# Altium schematic sheet TI_电机驱动2.0.SchDoc
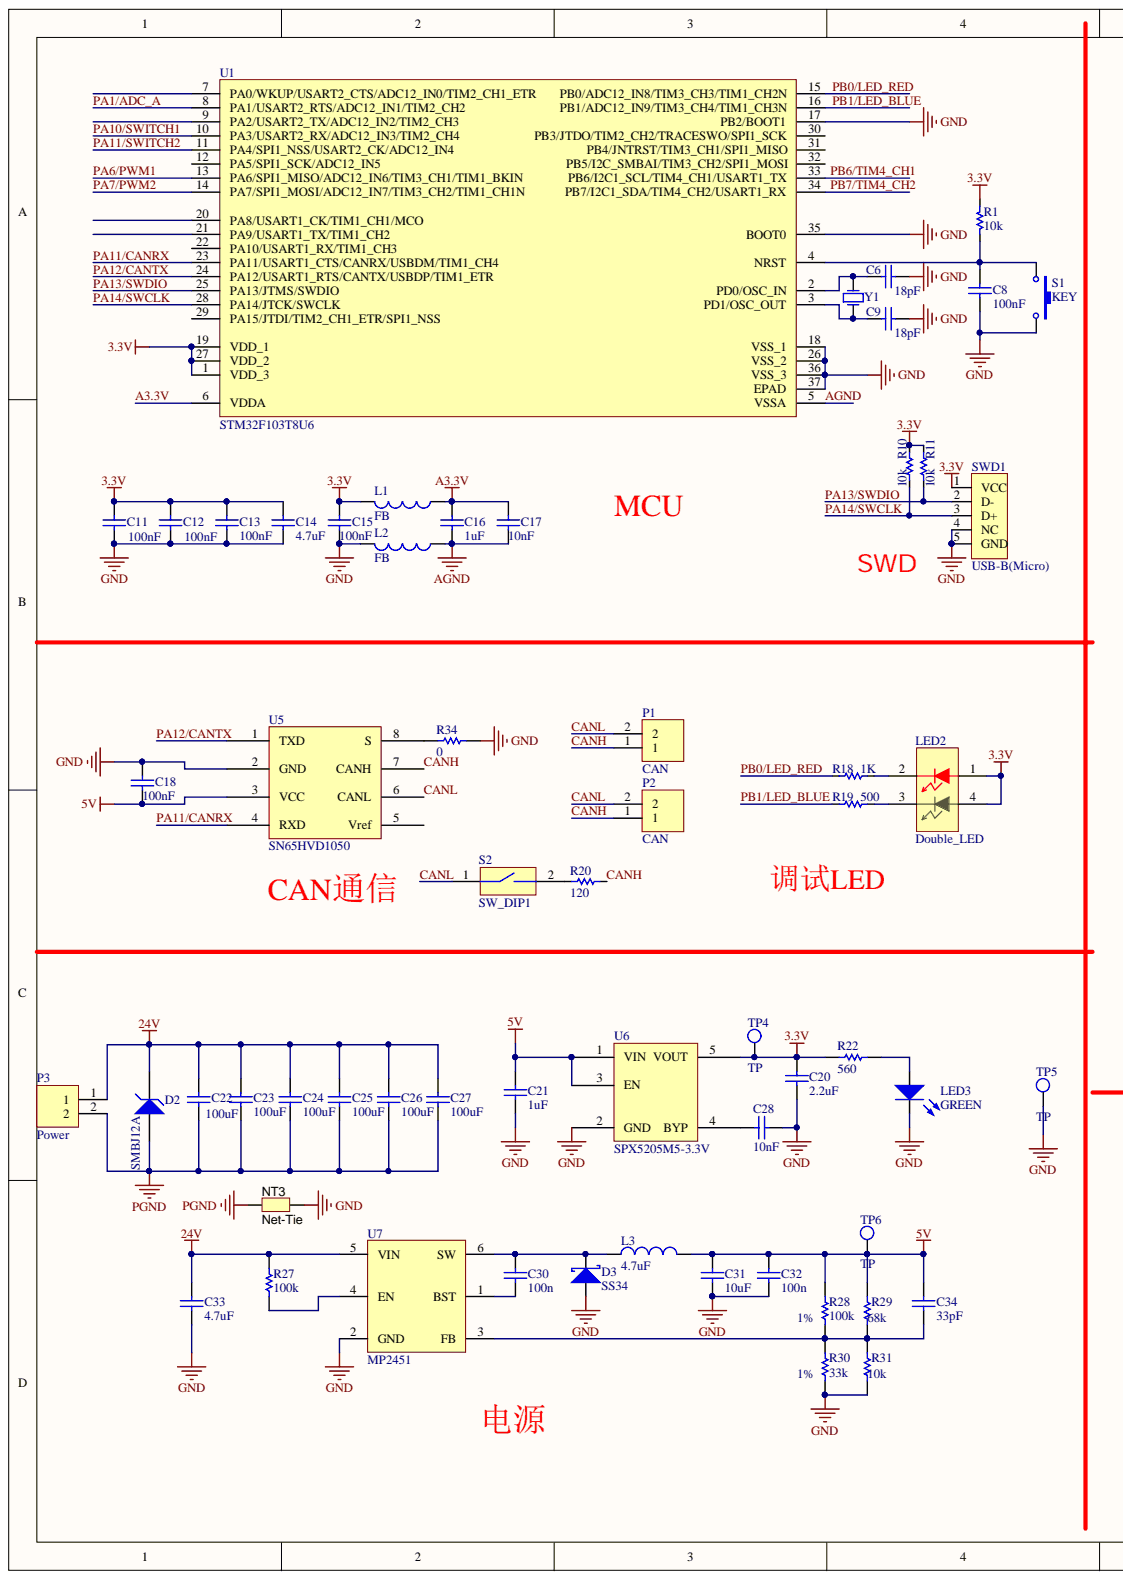
[diagram p0: TI_电机驱动2.0.SchDoc, left]
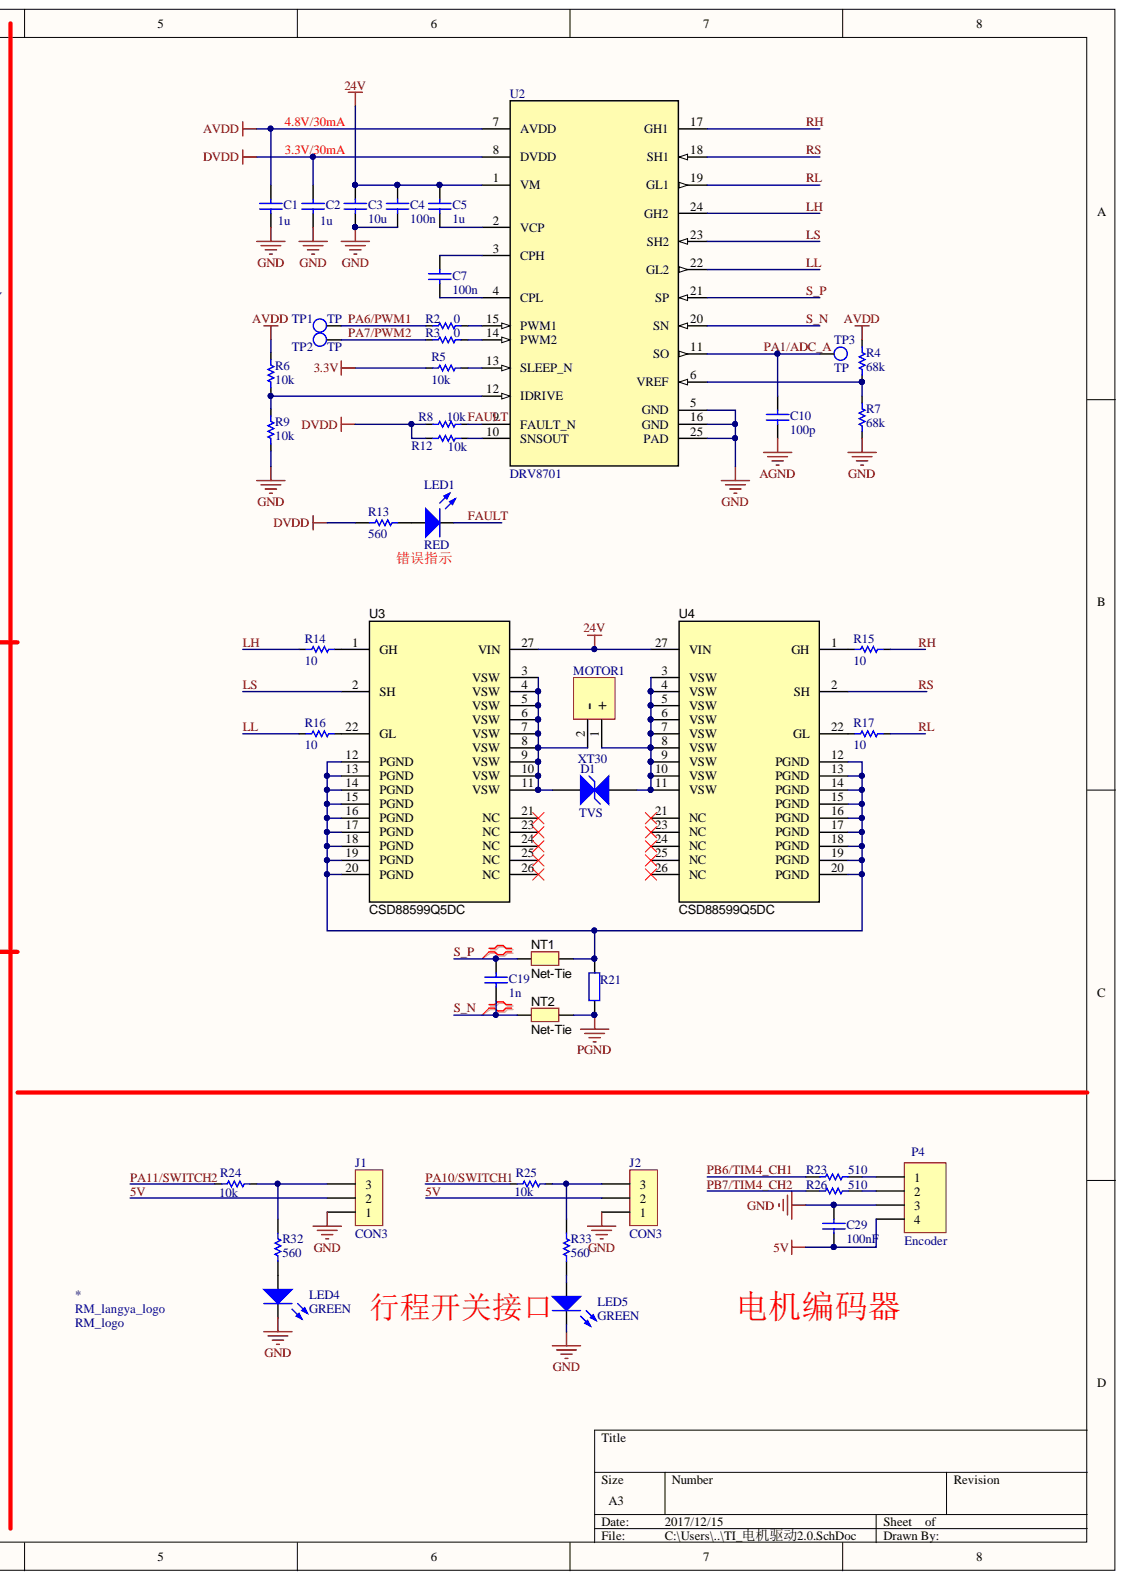
[diagram p1: TI_电机驱动2.0.SchDoc, right]

## Parts
*  RM_logo  [RM_logo 300mil*350]
C1  value 1u  [0603C]  (hidden comment: 1uF/0603/50V)
C2  value 1u  [0603C]  (hidden comment: 1uF/0603/50V)
C3  value 10u  [0805C]  (hidden comment: 1uF/0603/50V)
C4  value 100n  [0603C]  (hidden comment: 1uF/0603/50V)
C5  value 1u  [0603C]  (hidden comment: 1uF/0603/50V)
C6  18pF  [0603C]
C7  value 100n  [0603C]  (hidden comment: 1uF/0603/50V)
C8  100nF  [0603C]
C9  18pF  [0603C]
C10  value 100p  [0603C]  (hidden comment: 1uF/0603/50V)
C11  100nF  [0603C]
C12  100nF  [0603C]
C13  100nF  [0603C]
C14  4.7uF  [0603C]
C15  100nF  [0603C]
C16  1uF  [0603C]
C17  10nF  [0603C]
C18  100nF  [0603C]
C19  value 1n  [0603C]  (hidden comment: 1uF/0603/50V)
C20  value 2.2uF  [0603C]  (hidden comment: 1uF/0603/50V)
C21  value 1uF  [0603C]  (hidden comment: 1uF/0603/50V)
C22  value 100uF  [1206C]  (hidden comment: 1uF/0603/50V)
C23  value 100uF  [1206C]  (hidden comment: 1uF/0603/50V)
C24  value 100uF  [1206C]  (hidden comment: 1uF/0603/50V)
C25  value 100uF  [1206C]  (hidden comment: 1uF/0603/50V)
C26  value 100uF  [1206C]  (hidden comment: 1uF/0603/50V)
C27  value 100uF  [1206C]  (hidden comment: 1uF/0603/50V)
C28  value 10nF  [0603C]  (hidden comment: 1uF/0603/50V)
C29  value 100nF  [0603c]  (hidden comment: Cap)
C30  value 100n  [0603C]  (hidden comment: 1uF/0603/50V)
C31  value 10uF  [0805C]  (hidden comment: 1uF/0603/50V)
C32  value 100n  [0603C]  (hidden comment: 1uF/0603/50V)
C33  value 4.7uF  [1206C]  (hidden comment: 1uF/0603/50V)
C34  value 33pF  [0603C]  (hidden comment: 1uF/0603/50V)
D1  TVS  [SMB/DO-214AA]
D2  SMBJ12A  [SMB/DO-214AA]
D3  SS34  [SOD-323]
J1  CON3  [A1254-03AWB]
J2  CON3  [A1254-03AWB]
L1  FB  [0603L]
L2  FB  [0603L]
L3  value 4.7uF  [4020L]  (hidden comment: 10mH/0402)
LED1  RED  [0603LED_R]
LED2  Double_LED  [0805LEDX2]
LED3  GREEN  [0603LED_G]
LED4  GREEN  [0603LED_G]
LED5  GREEN  [0603LED_G]
MOTOR1  XT30  [XT30PW-Female]
NT1  Net-Tie  [Tie_1]
NT2  Net-Tie  [Tie_1]
NT3  Net-Tie  [Tie_2]
P1  CAN  [MX3.0MM 2X1AW]
P2  CAN  [MX3.0MM 2X1AW]
P3  Power  [XT30PW-Male]
P4  Encoder  [MX3.0mm 2X2AW]
R1  10k  [0603R]
R2  value 0  [0603R]  (hidden comment: 1K/5%)
R3  value 0  [0603R]  (hidden comment: 1K/5%)
R4  value 68k  [0603R]  (hidden comment: 1K/5%)
R5  value 10k  [0603R]  (hidden comment: 1K/5%)
R6  value 10k  [0603R]  (hidden comment: 1K/5%)
R7  value 68k  [0603R]  (hidden comment: 1K/5%)
R8  value 10k  [0603R]  (hidden comment: 1K/5%)
R9  value 10k  [0603R]  (hidden comment: 1K/5%)
R10  10k  [0603R]
R11  10k  [0603R]
R12  value 10k  [0603R]  (hidden comment: 1K/5%)
R13  value 560  [0603R]  (hidden comment: Res1)
R14  value 10  [0603R]  (hidden comment: 1K/5%)
R15  value 10  [0603R]  (hidden comment: 1K/5%)
R16  value 10  [0603R_1]  (hidden comment: 1K/5%)
R17  value 10  [0603R]  (hidden comment: 1K/5%)
R18  1K  [0603R]
R19  500  [0603R]
R20  value 120  [0603R]  (hidden comment: 1K/5%)
R21  0.05R/2512/1%  [2512]  (value not drawn)
R22  value 560  [0603R]  (hidden comment: Res1)
R23  value 510  [0603R]  (hidden comment: Res1)
R24  value 10k  [0603R]  (hidden comment: 1K/5%)
R25  value 10k  [0603R]  (hidden comment: 1K/5%)
R26  value 510  [0603R]  (hidden comment: Res1)
R27  value 100k  [0603R]  (hidden comment: Res1)
R28  1%  value 100k  [0603R]
R29  value 68k  [0603R]  (hidden comment: Res1)
R30  1%  value 33k  [0603R]
R31  value 10k  [0603R]  (hidden comment: Res1)
R32  value 560  [0603R_1]  (hidden comment: Res1)
R33  value 560  [0603R_1]  (hidden comment: Res1)
R34  value 0  [0603R_1]  (hidden comment: Res1)
S1  KEY  [SW-3x6x2.5mm]
S2  SW_DIP1  [SW_DIP_1X2]
SWD1  USB-B(Micro)  [USB-micro_A]
TP1  TP  [TP_SMT]
TP2  TP  [TP_SMT]
TP3  TP  [TP_SMT]
TP4  TP  [TP_SMT]
TP5  TP  [TP_VIA]
TP6  TP  [TP_SMT]
U1  STM32F103T8U6  [VFQFPN-36-M]
U2  DRV8701  [RGE0024F]
U3  CSD88599Q5DC  [DMM0022A]
U4  CSD88599Q5DC  [DMM0022A]
U5  SN65HVD1050  [SOIC-8]
U6  SPX5205M5-3.3V  [SOT-23-5 L]
U7  MP2451  [SOT23-6]
Y1  XTAL  [NX5032GA]  (value not drawn)

## Nets
3.3V: C11.2 C12.2 C13.1 C14.1 C15.1 C20.2 L1.1 LED2.1 LED2.4 R1.2 R5.1 R10.1 R11.1 R22.2 SWD1.1(VCC) TP4.1 U1.1(VDD_3) U1.19(VDD_1) U1.27(VDD_2) U6.5(VOUT)
24V: C3.2 C4.2 C5.2 C22.2 C23.2 C24.2 C25.2 C26.2 C27.2 C33.2 D2.2 P3.1 R27.2 U2.1(VM) U3.27(VIN) U4.27(VIN) U7.5(VIN)
AVDD: C1.2 R4.2 R6.2 U2.7(AVDD)
DVDD: C2.2 R8.1 R12.1 R13.2 U2.8(DVDD)
GND: C1.1 C2.1 C3.1 C4.1 C6.2 C8.2 C9.2 C11.1 C12.1 C13.2 C14.2 C15.2 C18.1 C20.1 C21.1 C28.1 C29.1 C31.1 C32.1 C33.1 D3.1 J1.1 J2.1 L2.1 LED3.2 LED4.2 LED5.2 NT3.2 P4.3 R7.1 R9.1 R30.1 R31.1 R34.1 S1.1 SWD1.4(NC) SWD1.5(GND) TP5.1 U1.17(PB2/BOOT1) U1.18(VSS_1) U1.26(VSS_2) U1.35(BOOT0) U1.36(VSS_3) U1.37(EPAD) U2.5(GND) U2.16(GND) U2.25(PAD) U5.2(GND) U6.2(GND) U7.2(GND)
PGND: C22.1 C23.1 C24.1 C25.1 C26.1 C27.1 D2.1 NT2.2 NT3.1 P3.2 R21.1
5V: C18.2 C21.2 C29.2 C31.2 C32.2 C34.1 J1.2 J2.2 L3.2 P4.4 R28.2 R29.2 TP6.1 U5.3(VCC) U6.1(VIN) U6.3(EN)
A3.3V: C16.2 C17.1 L1.2 U1.6(VDDA)
AGND: C10.1 C16.1 C17.2 L2.2 U1.5(VSSA)
CANH: P1.1 P2.1 R20.1 U5.7(CANH)
CANL: P1.2 P2.2 S2.1 U5.6(CANL)
FAULT: LED1.2 R8.2 U2.9(FAULT_N)
LH: R14.1 U2.24(GH2)
LL: R16.1 U2.22(GL2)
LS: U2.23(SH2) U3.2(SH)
PA1/ADC_A: C10.2 TP3.1 U1.8(PA1/USART2_RTS/ADC12_IN1/TIM2_CH2) U2.11(SO)
PA6/PWM1: R2.1 TP1.1 U1.13(PA6/SPI1_MISO/ADC12_IN6/TIM3_CH1/TIM1_BKIN)
PA7/PWM2: R3.1 TP2.1 U1.14(PA7/SPI1_MOSI/ADC12_IN7/TIM3_CH2/TIM1_CH1N)
PA10/SWITCH1: R25.2 U1.10(PA3/USART2_RX/ADC12_IN3/TIM2_CH4)
PA11/CANRX: U1.23(PA11/USART1_CTS/CANRX/USBDM/TIM1_CH4) U5.4(RXD)
PA11/SWITCH2: R24.2 U1.11(PA4/SPI1_NSS/USART2_CK/ADC12_IN4)
PA12/CANTX: U1.24(PA12/USART1_RTS/CANTX/USBDP/TIM1_ETR) U5.1(TXD)
PA13/SWDIO: R11.2 SWD1.2(D-) U1.25(PA13/JTMS/SWDIO)
PA14/SWCLK: R10.2 SWD1.3(D+) U1.28(PA14/JTCK/SWCLK)
PB0/LED_RED: R18.2 U1.15(PB0/ADC12_IN8/TIM3_CH3/TIM1_CH2N)
PB1/LED_BLUE: R19.2 U1.16(PB1/ADC12_IN9/TIM3_CH4/TIM1_CH3N)
PB6/TIM4_CH1: R23.1 U1.33(PB6/I2C1_SCL/TIM4_CH1/USART1_TX)
PB7/TIM4_CH2: R26.1 U1.34(PB7/I2C1_SDA/TIM4_CH2/USART1_RX)
RH: R15.2 U2.17(GH1)
RL: R17.2 U2.19(GL1)
RS: U2.18(SH1) U4.2(SH)
S_N: C19.1 NT2.1 U2.20(SN)
S_P: C19.2 NT1.1 U2.21(SP)
NetC5_1: C5.1 U2.2(VCP)
NetC6_1: C6.1 U1.2(PD0/OSC_IN) Y1.2
NetC7_1: C7.1 U2.4(CPL)
NetC7_2: C7.2 U2.3(CPH)
NetC8_1: C8.1 R1.1 S1.2 U1.4(NRST)
NetC9_1: C9.1 U1.3(PD1/OSC_OUT) Y1.1
NetC28_2: C28.2 U6.4(BYP)
NetC30_1: C30.1 U7.1(BST)
NetC30_2: C30.2 D3.2 L3.1 U7.6(SW)
NetC34_2: C34.2 R28.1 R29.1 R30.2 R31.2 U7.3(FB)
NetD1_1: D1.1 MOTOR1.1 U4.3(VSW) U4.4(VSW) U4.5(VSW) U4.6(VSW) U4.7(VSW) U4.8(VSW) U4.9(VSW) U4.10(VSW) U4.11(VSW)
NetD1_2: D1.2 MOTOR1.2 U3.3(VSW) U3.4(VSW) U3.5(VSW) U3.6(VSW) U3.7(VSW) U3.8(VSW) U3.9(VSW) U3.10(VSW) U3.11(VSW)
NetJ1_3: J1.3 R24.1 R32.2
NetJ2_3: J2.3 R25.1 R33.2
NetLED1_1: LED1.1 R13.1
NetLED2_2: LED2.2 R18.1
NetLED2_3: LED2.3 R19.1
NetLED3_1: LED3.1 R22.1
NetLED4_1: LED4.1 R32.1
NetLED5_1: LED5.1 R33.1
NetNT1_2: NT1.2 R21.2 U3.12(PGND) U3.13(PGND) U3.14(PGND) U3.15(PGND) U3.16(PGND) U3.17(PGND) U3.18(PGND) U3.19(PGND) U3.20(PGND) U4.12(PGND) U4.13(PGND) U4.14(PGND) U4.15(PGND) U4.16(PGND) U4.17(PGND) U4.18(PGND) U4.19(PGND) U4.20(PGND)
NetP4_1: P4.1 R23.2
NetP4_2: P4.2 R26.2
NetR2_2: R2.2 U2.15(PWM1)
NetR3_2: R3.2 U2.14(PWM2)
NetR4_1: R4.1 R7.2 U2.6(VREF)
NetR5_2: R5.2 U2.13(SLEEP_N)
NetR6_1: R6.1 R9.2 U2.12(IDRIVE)
NetR12_2: R12.2 U2.10(SNSOUT)
NetR14_2: R14.2 U3.1(GH)
NetR15_1: R15.1 U4.1(GH)
NetR16_2: R16.2 U3.22(GL)
NetR17_1: R17.1 U4.22(GL)
NetR20_2: R20.2 S2.2
NetR27_1: R27.1 U7.4(EN)
NetR34_2: R34.2 U5.8(S)

Not connected: U1 7(PA0/WKUP/USART2_CTS/ADC12_IN0/TIM2_CH1_ETR) 9(PA2/USART2_TX/ADC12_IN2/TIM2_CH3) 12(PA5/SPI1_SCK/ADC12_IN5) 20(PA8/USART1_CK/TIM1_CH1/MCO) 21(PA9/USART1_TX/TIM1_CH2) 22(PA10/USART1_RX/TIM1_CH3) 29(PA15/JTDI/TIM2_CH1_ETR/SPI1_NSS) 30(PB3/JTDO/TIM2_CH2/TRACESWO/SPI1_SCK) 31(PB4/JNTRST/TIM3_CH1/SPI1_MISO) 32(PB5/I2C_SMBAI/TIM3_CH2/SPI1_MOSI); U3 21(NC) 23(NC) 24(NC) 25(NC) 26(NC); U4 21(NC) 23(NC) 24(NC) 25(NC) 26(NC); U5 5(Vref)

## Library data of the parts
C1-C5 C7 C10 C19-C34 = Cap: Capacitor; Component Kind: Standard; LatestRevisionDate: 17-Jul-2002; LatestRevisionNote: Re-released for DXP Platform.; PackageDescription: Radial Cap, Thru-Hole; 2 Leads; 0.3 in Pin Spacing; PackageReference: RAD-0.3; Published: 8-Jun-2000; Signal Integrity: Cap; Simulation: CAP; Publisher: Altium Limited
  C1-C5 C7 C10 C19-C28 C30-C34: Library Name: MyComponents.IntLib
  C29: Library Name: Miscellaneous Devices.IntLib
C6 C8 C9 C11-C18 = Cap: Capacitor; Value: 100pF; Component Kind: Standard; LatestRevisionDate: 17-Jul-2002; LatestRevisionNote: Re-released for DXP Platform.; PackageDescription: Radial Cap, Thru-Hole; 2 Leads; 0.3 in Pin Spacing; PackageReference: RAD-0.3; Published: 8-Jun-2000; Signal Integrity: Cap; Simulation: CAP; Publisher: Altium Limited
  C6 C8 C9 C11-C17: Library Name: MyComponents.SchLib
  C18: Library Name: MyComponents.IntLib
D2 = D Zener: Zener Diode; Component Kind: Standard; LatestRevisionDate: 17-Jul-2002; LatestRevisionNote: Re-released for DXP Platform.; Library Name: MyComponents.IntLib; PackageDescription: Diode, Thru-Hole; 2 Leads; 0.7 in Pin Spacing; PackageReference: DIODE-0.7; Published: 8-Jun-2000; Signal Integrity: D Zener; Simulation: ZENER; Publisher: Altium Limited
D3 = D Schottky: Schottky Diode; Code_JEDEC: DO-214-AA; Component Kind: Standard; LatestRevisionDate: 17-Jul-2002; LatestRevisionNote: Re-released for DXP Platform.; Library Name: MyComponents.IntLib; PackageDescription: DO-214-AA/SMB; 2 C-Bend Leads; Body 5.3 x 3.6 mm, inc. leads (LxW); PackageReference: SMB; Published: 8-Jun-2000; Signal Integrity: D Schottky; Simulation: SKYDIODE; Publisher: Altium Limited
J1 J2 = CON3: Connector; Component Kind: Standard; Library Name: Robomasters.SchLib
L1 L2 = Inductor: Code_IEC: 1005; Value: 10mH; Code_JEITA: 0402; Component Kind: Standard; Description: Inductor; LatestRevisionDate: 29-May-2009; LatestRevisionNote: IPC-7351 Footprint Added.; Library Name: MyComponents.IntLib; PackageDescription: Chip Inductor; PackageReference: 0402-A; Published: 8-Jun-2000; Signal Integrity: Inductor; Simulation: INDUCTOR; Publisher: Altium Limited
L3 = Inductor: Code_IEC: 1005; Code_JEITA: 0402; Component Kind: Standard; Description: Inductor; LatestRevisionDate: 29-May-2009; LatestRevisionNote: IPC-7351 Footprint Added.; Library Name: MyComponents.IntLib; PackageDescription: Chip Inductor; PackageReference: 0402-A; Published: 8-Jun-2000; Signal Integrity: Inductor; Simulation: INDUCTOR; Publisher: Altium Limited
LED1 LED3-LED5 = LED: Typical RED, GREEN, YELLOW, AMBER GaAs LED; Component Kind: Standard; LatestRevisionDate: 17-Jul-2002; LatestRevisionNote: Re-released for DXP Platform.; Library Name: MyComponents.IntLib; PackageDescription: SM LED; 2 Flat Leads; PackageReference: 3.2X1.6X1.1; PackageVersion: Feb-2002; Published: 8-Jun-2000; Simulation: LED2; Publisher: Altium Limited
LED2 = Double_Color LED: Component Kind: Standard; Library Name: MyComponents.SchLib
NT1-NT3 = Net-Tie: Single point connection between nets.; SymbolCreator: codlsc; Component Kind: Net Tie; LatestRevisionDate: 2013-09-07; LatestRevisionNote: Added Alternate PartNumber and Alternate Manufacturer parameters to appropriate components.; Library Name: L-components.SchLib; MountType: SMT; SymbolVersion: 2009-07-01; Publisher: Texas Instruments
P1 P2 = MX3.0_2X1: Component Kind: Standard; Library Name: MyComponents.IntLib
P3 = MX5.5_2X1: Component Kind: Standard; Library Name: MyComponents.IntLib
P4 = Header 4: Header, 4-Pin; Component Kind: Standard; LatestRevisionDate: 17-Jul-2002; LatestRevisionNote: Re-released for DXP Platform.; Library Name: Miscellaneous Connectors.IntLib; Signal Integrity: Connector; Publisher: Altium Limited
R1 R10 R11 R19 = Res1: Resistor; Value: 1K; Component Kind: Standard; LatestRevisionDate: 17-Jul-2002; LatestRevisionNote: Re-released for DXP Platform.; PackageDescription: Axial Device, Thru-Hole; 2 Leads; 0.3 in Pin Spacing; PackageReference: AXIAL-0.3; Published: 8-Jun-2000; Signal Integrity: Res; Simulation: RESISTOR; Publisher: Altium Limited
  R1 R19: Library Name: MyComponents.SchLib
  R10 R11: Library Name: MyComponents.IntLib
R2-R9 R12-R18 R20 R22-R34 = Res1: Resistor; Component Kind: Standard; LatestRevisionDate: 17-Jul-2002; LatestRevisionNote: Re-released for DXP Platform.; PackageDescription: Axial Device, Thru-Hole; 2 Leads; 0.3 in Pin Spacing; PackageReference: AXIAL-0.3; Published: 8-Jun-2000; Signal Integrity: Res; Simulation: RESISTOR; Publisher: Altium Limited
  R2-R9 R12 R14-R17 R20 R24 R25: Library Name: MyComponents.IntLib
  R13 R22 R23 R26-R34: Library Name: Miscellaneous Devices.IntLib
  R18: Library Name: MyComponents.SchLib; Value: 1K
R21 = Res2: Resistor; Value: 0.01R; Component Kind: Standard; LatestRevisionDate: 17-Jul-2002; LatestRevisionNote: Re-released for DXP Platform.; Library Name: MyComponents.IntLib; PackageDescription: Axial Device, Thru-Hole; 2 Leads; 0.4 in Pin Spacing; PackageReference: AXIAL-0.4; Published: 8-Jun-2000; Signal Integrity: Res; Simulation: RESISTOR; Publisher: Altium Limited
S1 = PushButton_2p: Button; Component Kind: Standard; LatestRevisionDate: 17-Jul-2002; LatestRevisionNote: Re-released for DXP Platform.; Library Name: MyComponents.IntLib; PackageDescription: Switch; 2 Leads; PackageReference: SPST-2; Published: 24-Mar-1999; Publisher: Altium Limited
S2 = SW_DIP 1: Component Kind: Standard; Library Name: MyComponents.IntLib
SWD1 = USB-micro: Component Kind: Standard; Library Name: MyComponents.IntLib
TP1-TP6 = TP: Test Point; Component Kind: Standard; Library Name: MyComponents.SchLib
U2 = DRV8701: 全桥驱动; Component Kind: Standard; Library Name: L-components.SchLib
U3 U4 = CSD88599Q5DC: 60 V Half-Bridge NexFE Power Block, DMM0022A (LSON-CLIP-27); Alternate Manufacturer: Texas Instruments; Alternate PartNumber: CSD88599Q5DCT; CompType: IC; SizeArea_mm2: 30; SizeAreaWB_mm2: 56; SizeX_mm: 5; SizeY_mm: 6; SizeZ_mm: 1.05; SymbolCreator: Altium; U/M: EA; Component Kind: Standard; ComponentLink1Description: Datasheet Link; ComponentLink1URL: https://sps06.itg.ti.com/sites/KMS/crossdivteams/altium/docs/ComponentDatasheets/CSD88599Q5DC.pdf; LatestRevisionDate: 2016-12-06; Library Name: MyComponents.IntLib; Manufacturer: Texas Instruments; MountType: SMT; PackageDescription: LSON-CLIP-27; PackageReference: DMM0022A; PartNumber: CSD88599Q5DC; RoHS: Y; SymbolVersion: 2016-08-25; Publisher: Texas Instruments
U5 = TJA1050: Component Kind: Standard; Library Name: MyComponents.IntLib
U7 = MP2451: 开关电源0.6A; Component Kind: Standard; Library Name: MyComponents.IntLib
Y1 = XTAL: Crystal Oscillator; Component Kind: Standard; LatestRevisionDate: 17-Jul-2002; LatestRevisionNote: Re-released for DXP Platform.; Library Name: MyComponents.SchLib; PackageDescription: Crystal, Thru-Hole; 2 Leads; Body 3.1 x 8.2 mm (Dia.xH); PackageReference: R38; Published: 8-Jun-2000; Simulation: XTAL; Publisher: Altium Limited
* = RM_logo: Component Kind: Standard; Library Name: L-components.SchLib
D1 = TVS: Component Kind: Standard; Library Name: MyComponents.IntLib
MOTOR1 = XT30: Component Kind: Standard; Library Name: MyComponents.IntLib
U1 = STM32F103T8U6: Component Kind: Standard; Library Name: MyComponents.SchLib
U6 = SPX5205M5-3.3V: Component Kind: Standard; Library Name: MyComponents.IntLib
